# Altium schematic sheet MAIN.SchDoc(MAIN)
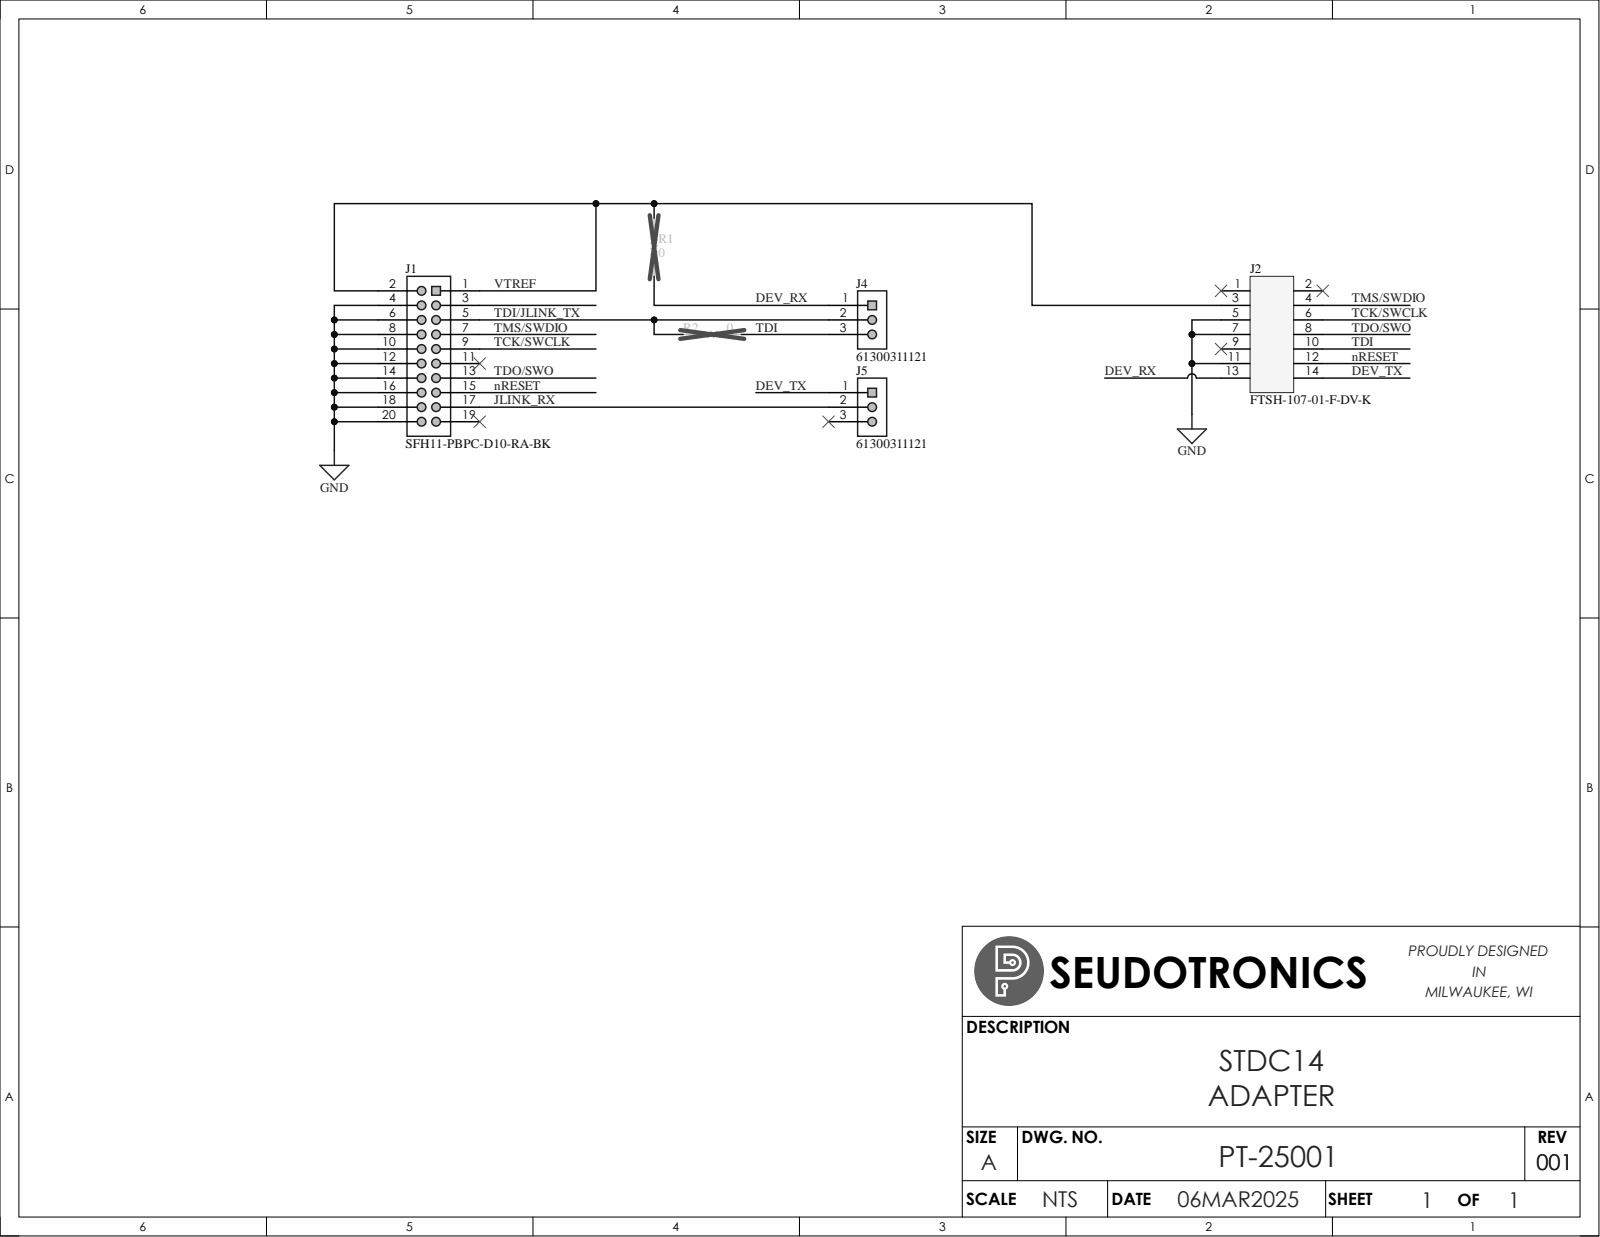
[diagram p0: MAIN.SchDoc]

## Parts
J1  SFH11-PBPC-D10-RA-BK  [RHDRRA20W60P254_10X2_3048X850H600]
J2  FTSH-107-01-F-DV-K  [FTSH-107/105]
J4  61300311121  [HDRV3W64P254_3X1_762X254H854]
J5  61300311121  [HDRV3W64P254_3X1_762X254H854]
R1  RC0805FR-070RL  [R0805N]  (value not drawn)
R2  RC0805FR-070RL  [R0805N]  (value not drawn)

## Nets
GND: J1.4 J1.6 J1.8 J1.10 J1.12 J1.14 J1.16 J1.18 J1.20 J2.5 J2.7 J2.11
DEV_RX: J2.13 J4.1 R1.1
DEV_TX: J2.14 J5.1
JLINK_RX: J1.17 J5.2
nRESET: J1.15 J2.12
TCK/SWCLK: J1.9 J2.6
TDI: J2.10 J4.3 R2.1
TDI/JLINK_TX: J1.5 J4.2 R2.2
TDO/SWO: J1.13 J2.8
TMS/SWDIO: J1.7 J2.4
VTREF: J1.1 J1.2 J2.3 R1.2

Not connected: J1 3 11 19; J2 1 2 9; J5 3

## Library data of the parts
J1 = CONN-00032-01: CONN HDR 20POS 0.1 GOLD PCB R/A; MFG: Sullins Connector Solutions; Pitch: 0.100" (2.54mm); Positions: 20; Component Kind: Standard; MFG-PN: SFH11-PBPC-D10-RA-BK; Orientation: Right Angle; Library Name: Sentry Equipment
J2 = CONN-00007-04: CONN HEADER SMD 14POS 1.27MM; MFG: Samtec Inc.; Positions: 14; Component Kind: Standard; MFG-PN: FTSH-107-01-F-DV-K; Orientation: Vertical; Pitch: 1.27mm; Library Name: Sentry Equipment
J4 J5 = CONN-00010-02: CONN HEADER VERT 3POS 2.54MM; MFG: Würth Elektronik; Positions: 3; SEQ-PN: 4-08023R; Component Kind: Standard; MFG-PN: 61300311121; Orientation: Vertical; Pitch: 2.54mm; Library Name: Sentry Equipment
R1 R2 = RES-00006-01: RES 0 OHM JUMPER 1/8W 0805; MFG: YAGEO; Power: 125mW; Resistance: 0; Component Kind: Standard; Composition: Thick Film; MFG-PN: RC0805FR-070RL; Mounting: SMT; Package Size: 0805; Library Name: Sentry Equipment
